# Altium schematic sheet QUECTEL_L89.SchDoc
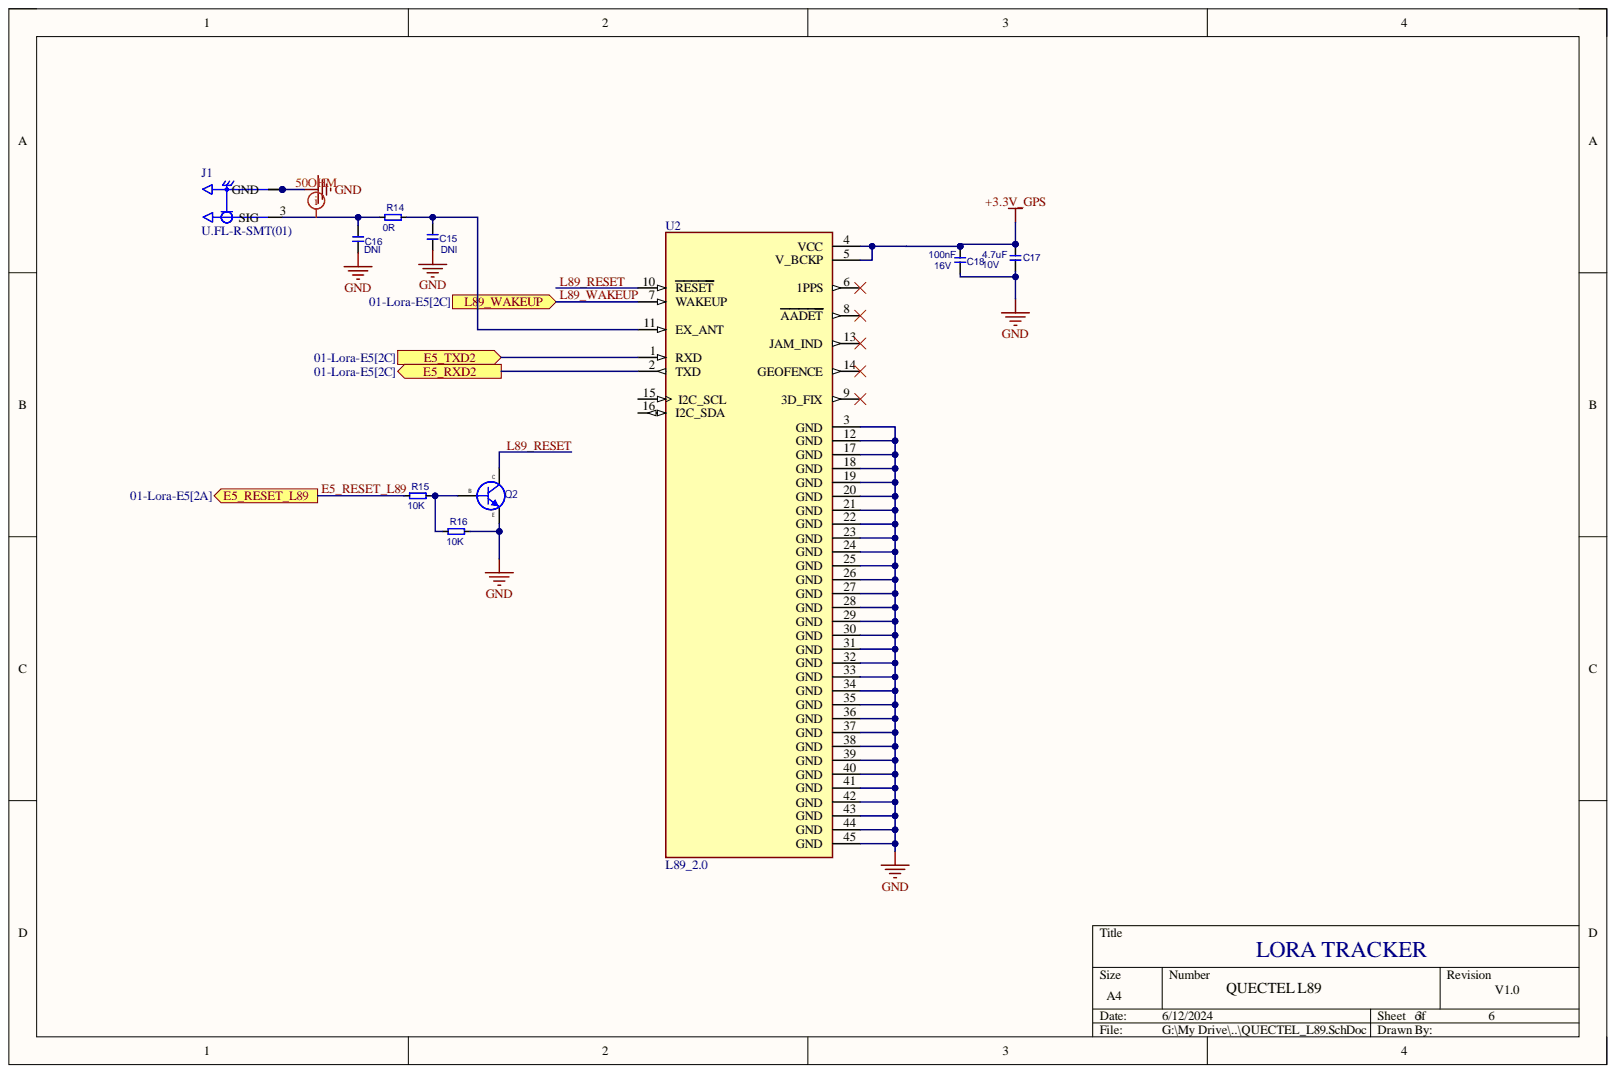
[diagram p0: QUECTEL_L89.SchDoc]

## Parts
C15  DNI  [CAPC1005X60N]
C16  DNI  [CAPC1005X60N]
C17  4.7uF  [T_CAPC0603X33N]
C18  100nF  Rating: 16V  [CAPC1005X60N]
J1  U.FL-R-SMT(01)  [HRS_U.FL-R-SMT(01)]
Q2  ON Semiconductor''  [SOT95P240X120-3N]  (value not drawn)
R14  0R  [RESC1005X40N]
R15  10K  [RESC1005X40N]
R16  10K  [RESC1005X40N]
U2  L89_2.0  [L89_2.0]

## Ports
E5_RESET_L89, E5_RXD2, E5_TXD2, L89_WAKEUP

## Nets
+3.3V_GPS: C17.1 C18.1 U2.4(VCC) U2.5(V_BCKP)
GND: C15.2 C16.2 C17.2 C18.2 J1.1 J1.2 Q2.2 R16.2 U2.3(GND) U2.12(GND) U2.17(GND) U2.18(GND) U2.19(GND) U2.20(GND) U2.21(GND) U2.22(GND) U2.23(GND) U2.24(GND) U2.25(GND) U2.26(GND) U2.27(GND) U2.28(GND) U2.29(GND) U2.30(GND) U2.31(GND) U2.32(GND) U2.33(GND) U2.34(GND) U2.35(GND) U2.36(GND) U2.37(GND) U2.38(GND) U2.39(GND) U2.40(GND) U2.41(GND) U2.42(GND) U2.43(GND) U2.44(GND) U2.45(GND)
E5_RESET_L89: R15.1 ; port E5_RESET_L89
E5_RXD2: U2.2(TXD) ; port E5_RXD2
E5_TXD2: U2.1(RXD) ; port E5_TXD2
L89_RESET: Q2.3 U2.10(RESET)
L89_WAKEUP: U2.7(WAKEUP) ; port L89_WAKEUP
NetC15_1: C15.1 R14.2 U2.11(EX_ANT)
NetC16_1: C16.1 J1.3 R14.1
NetQ2_1: Q2.1 R15.2 R16.1

Not connected: U2 6(1PPS) 8(AADET) 9(3D_FIX) 13(JAM_IND) 14(GEOFENCE) 15(I2C_SCL) 16(I2C_SDA)

## Library data of the parts
C15 C16 C18 = C-X7R-100nF-16V-10%-0603: CAP CER 0.1UF 16V X7R 0402; MP: CC0402KRX7R7BB104; Tolerance: 10%; Component Kind: Standard; Type: X7R; Library Name: Evaluation Board.SchLib
  C15 C16: Value: DNI
  C18: Value: 100nF
C17 = C-X5R-4.7uF-10V-10%-0603: Capacitor Ceramic X5R 4.7uF 10V 10% 0603; Manufacturer Name: Generic; MP: CC0603KRX5R6BB475; Component Kind: Standard; Rating: 10V; Tolerance: 10%; Type: X5R; Value: 4.7uF; Library Name: Iris.SchLib
J1 = U.FL-R-SMT(01): U.FL (UMCC) Connector Receptacle, Male Pin 50Ohm Surface Mount Solder. RF Connectors / Coaxial Connectors; MF: Hirose; Availability: In Stock; Check_prices: https://www.snapeda.com/parts/U.FL-R-SMT(01)/Hirose+Electric+Co+Ltd/view-part/?ref=eda; Component Kind: Standard; Library Name: U.FL-R-SMT_01_.IntLib; MP: U.FL-R-SMT(01); Package: None; Price: None; Purchase-URL: https://pricing.snapeda.com/search/part/U.FL-R-SMT(01)/?ref=eda; SnapEDA_Link: https://www.snapeda.com/parts/U.FL-R-SMT(01)/Hirose+Electric+Co+Ltd/view-part/?ref=snap
Q2 = MMBT3904LT1G: BJT NPN,40V,200MA,SOT23-3,SMD; No: 3; BJT Type: NPN; Component Kind: Standard; Database Table Name: BJT; Ic (Max): 200mA; Library Name: Component Library.DbLib; Manufacturer: ON Semiconductor; Mounting Type: Surface Mount (SMD); MP: MMBT3904LT1G; Package: SOT23-3 (SOT23, TO236AB, SOT346, SC59A, TO236AA, SMT3); Part Number: MMBT3904LT1G; Vce (Max): 40V
R14 = R-22R-63mW-5%-0402: RES 0 OHM JUMPER 1/16W 0402; MP: RC0402JR-070RL; Rating: 63mW; Tolerance: 5%; Component Kind: Standard; Value: 0R; Library Name: Iris.SchLib
R15 R16 = R-22R-63mW-5%-0402: Resistor 10K ohm 63mW 5% 0402; MP: RC0402JR-0710KL; Component Kind: Standard; Rating: 63mW; Tolerance: 5%; Value: 10K; Library Name: Iris.SchLib
U2 = L89_2.0: L89 is a high performance IRNSS-enabled GNSS module, capable of acquiring and tracing GPS, IRNSS, GLONASS, BeiDou, Galileo and QZSS signals.; MF: Quectel; MP: L89 2.0; Check_prices: https://www.snapeda.com/parts/L89%202.0/Quectel/view-part/?ref=eda; Component Kind: Standard; Library Name: L89_2.0.IntLib; Package: Package; SnapEDA_Link: https://www.snapeda.com/parts/L89%202.0/Quectel/view-part/?ref=snap
